# Altium schematic sheet Power_24V.SchDoc(Power_24V)
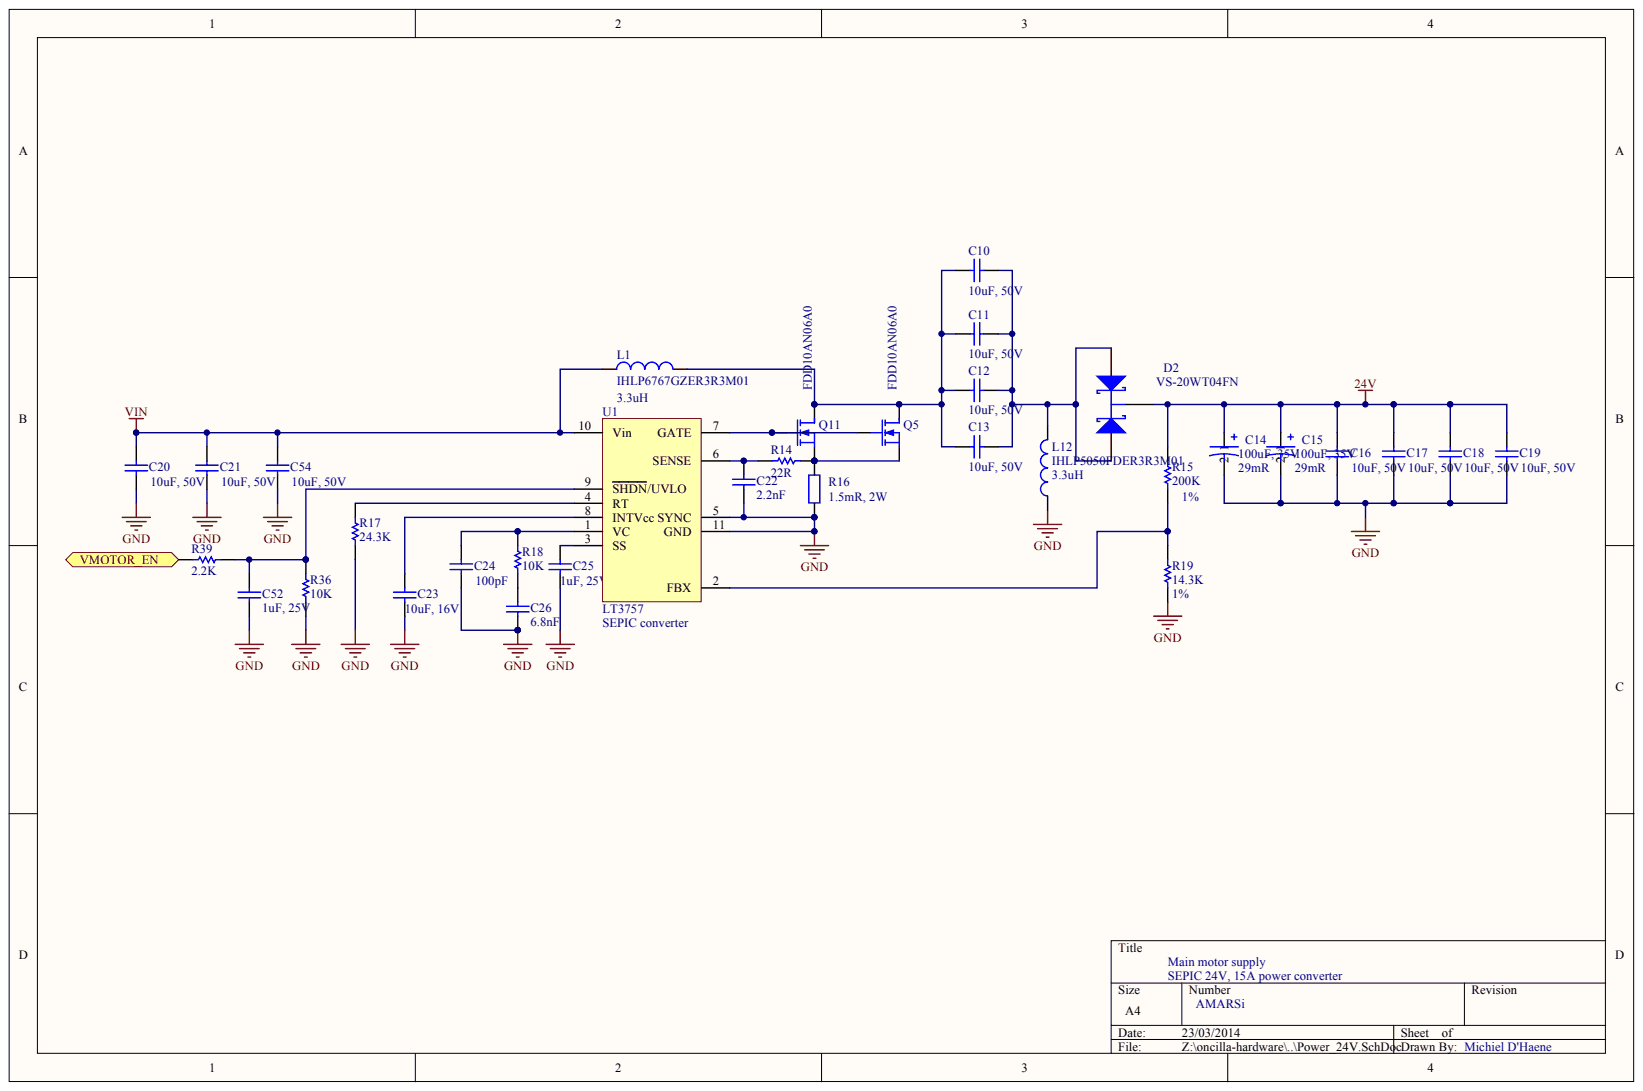
[diagram p0: Power_24V.SchDoc]

## Parts
C10  10uF, 50V  Voltage: 50V
C11  10uF, 50V  Voltage: 50V
C12  10uF, 50V  Voltage: 50V
C13  10uF, 50V  Voltage: 50V
C14  value 100uF, 35V  ESR: 29mR  (hidden comment: 100uF, 35V, 29mR)
C15  value 100uF, 35V  ESR: 29mR  (hidden comment: 100uF, 35V, 29mR)
C16  10uF, 50V  (value not drawn)
C17  10uF, 50V  Voltage: 50V
C18  10uF, 50V  Voltage: 50V
C19  10uF, 50V  Voltage: 50V
C20  10uF, 50V
C21  10uF, 50V  Voltage: 50V
C22  2.2nF
C23  10uF, 16V
C24  100pF
C25  1uF, 25V
C26  6.8nF
C52  1uF, 25V
C54  10uF, 50V  Voltage: 50V
D2  VS-20WT04FN
L1  IHLP6767GZER3R3M01  value 3.3uH
L12  IHLP5050FDER3R3M01  value 3.3uH
Q5  FDD10AN06A0
Q11  FDD10AN06A0
R14  22R
R15  value 200K  Precision: 1%  (hidden comment: 200K, 1%)
R16  1.5mR, 2W
R17  24.3K
R18  10K
R19  value 14.3K  Precision: 1%  (hidden comment: 14.3K, 1%)
R36  10K
R39  2.2k
U1  LT3757

## Ports
VMOTOR_EN

## Nets
24V: C14.2 C15.2 C16.2 C17.2 C18.2 C19.2 D2.4 R15.2
GND: C14.1 C15.1 C16.1 C17.1 C18.1 C19.1 C20.1 C21.1 C22.1 C23.1 C24.1 C25.1 C26.1 C52.1 C54.1 L12.1 R16.1 R17.1 R19.1 R36.1 U1.5(SYNC) U1.11(GND)
VIN: C20.2 C21.2 C54.2 L1.1 U1.10(Vin)
VMOTOR_EN: R39.2 ; port VMOTOR_EN
NetC10_1: C10.1 C11.1 C12.1 C13.1 D2.1 D2.3 L12.2
NetC10_2: C10.2 C11.2 C12.2 C13.2 L1.2 Q5.D Q11.D
NetC22_2: C22.2 R14.1 U1.6(SENSE)
NetC23_2: C23.2 U1.8(INTVcc)
NetC24_2: C24.2 R18.2 U1.1(VC)
NetC25_2: C25.2 U1.3(SS)
NetC26_2: C26.2 R18.1
NetC52_2: C52.2 R36.2 R39.1 U1.9(SHDN/UVLO)
NetQ5_G: Q5.G Q11.G U1.7(GATE)
NetQ5_S: Q5.S Q11.S R14.2 R16.2
NetR15_1: R15.1 R19.2 U1.2(FBX)
NetR17_2: R17.2 U1.4(RT)

## Library data of the parts
C23 = (no symbol name): Voltage: 10V; Published: 8-Jun-2000; LatestRevisionDate: 29-May-2009; LatestRevisionNote: IPC-7351 Footprint Added.; PackageReference: C0805; PackageDescription: Chip Capacitor; Value: 10uF; Publisher: Altium Limited
D2 = (no symbol name): Note: Pin 2 is trimmed and not drawn; Published: 8-Jun-2000; LatestRevisionDate: 17-Jul-2002; LatestRevisionNote: Re-released for DXP Platform.; DatasheetDocument: Apr-1997; PackageDocument: Feb-2003; PackageReference: TO-252AA; Code_JEDEC: TO-252-AA; ComponentLink1Description: Manufacturer Link; ComponentLink1URL: http://www.irf.com/; ComponentLink2Description: Datasheet; ComponentLink2URL: http://www.irf.com/product-info/datasheets/data/12cwq06fn.pdf; Publisher: Altium Limited
L1 = (no symbol name): Value: 3.3uH
L12 = (no symbol name): Code_IEC: 1005; Published: 8-Jun-2000; LatestRevisionDate: 17-Jul-2002; LatestRevisionNote: Re-released for DXP Platform.; PackageReference: 0402-A; Code_JEITA: 0402; Publisher: Altium Limited
R39 = (no symbol name): Precision: 5%; Published: 8-Jun-2000; LatestRevisionDate: 17-Jul-2002; LatestRevisionNote: Re-released for DXP Platform.; PackageReference: AXIAL-0.5; Value: 2.2K; Publisher: Altium Limited
C10-C22 C24-C26 C52 C54 Q5 Q11 R14-R19 R36 U1 = (no symbol name)
  C10-C13 C17-C19 C21 C54: Published: 8-Jun-2000; LatestRevisionDate: 29-May-2009; LatestRevisionNote: IPC-7351 Footprint Added.; PackageReference: C1206; PackageDescription: Chip Capacitor; Value: 10uF
  C14: Value: 100uF, 35V
  C16 C20: Published: 8-Jun-2000; LatestRevisionDate: 29-May-2009; LatestRevisionNote: IPC-7351 Footprint Added.; PackageReference: C1206; PackageDescription: Chip Capacitor; Value: 10uF; Voltage: 50V
  C22: Published: 8-Jun-2000; LatestRevisionDate: 29-May-2009; LatestRevisionNote: IPC-7351 Footprint Added.; PackageReference: C1206; PackageDescription: Chip Capacitor; Value: 2.2nF
  C24 C26: Published: 8-Jun-2000; LatestRevisionDate: 17-Jul-2002; LatestRevisionNote: Re-released for DXP Platform.; PackageReference: RAD-0.3
  C25: Published: 8-Jun-2000; LatestRevisionDate: 17-Jul-2002; LatestRevisionNote: Re-released for DXP Platform.; PackageReference: RAD-0.3; Value: 1uF, 25V
  C52: Published: 8-Jun-2000; LatestRevisionDate: 29-May-2009; LatestRevisionNote: IPC-7351 Footprint Added.; PackageReference: C1206; PackageDescription: Chip Capacitor; Value: 1uF, 25V
  R14: Published: 8-Jun-2000; LatestRevisionDate: 17-Jul-2002; LatestRevisionNote: Re-released for DXP Platform.; PackageReference: AXIAL-0.5; Value: 22R
  R15 R19: Published: 8-Jun-2000; LatestRevisionDate: 17-Jul-2002; LatestRevisionNote: Re-released for DXP Platform.; PackageReference: AXIAL-0.5
  R16: Published: 8-Jun-2000; LatestRevisionDate: 17-Jul-2002; LatestRevisionNote: Re-released for DXP Platform.; PackageReference: AXIAL-0.4; Value: 1.5mR, 2W
  R17: Published: 8-Jun-2000; LatestRevisionDate: 17-Jul-2002; LatestRevisionNote: Re-released for DXP Platform.; PackageReference: AXIAL-0.5; Value: 24.3K
  R18 R36: Published: 8-Jun-2000; LatestRevisionDate: 17-Jul-2002; LatestRevisionNote: Re-released for DXP Platform.; PackageReference: AXIAL-0.5; Value: 10K
  C10-C13 C16-C22 C24-C26 C52 C54 R14-R19 R36: Publisher: Altium Limited
